# Altium schematic sheet main.SchDoc(main)
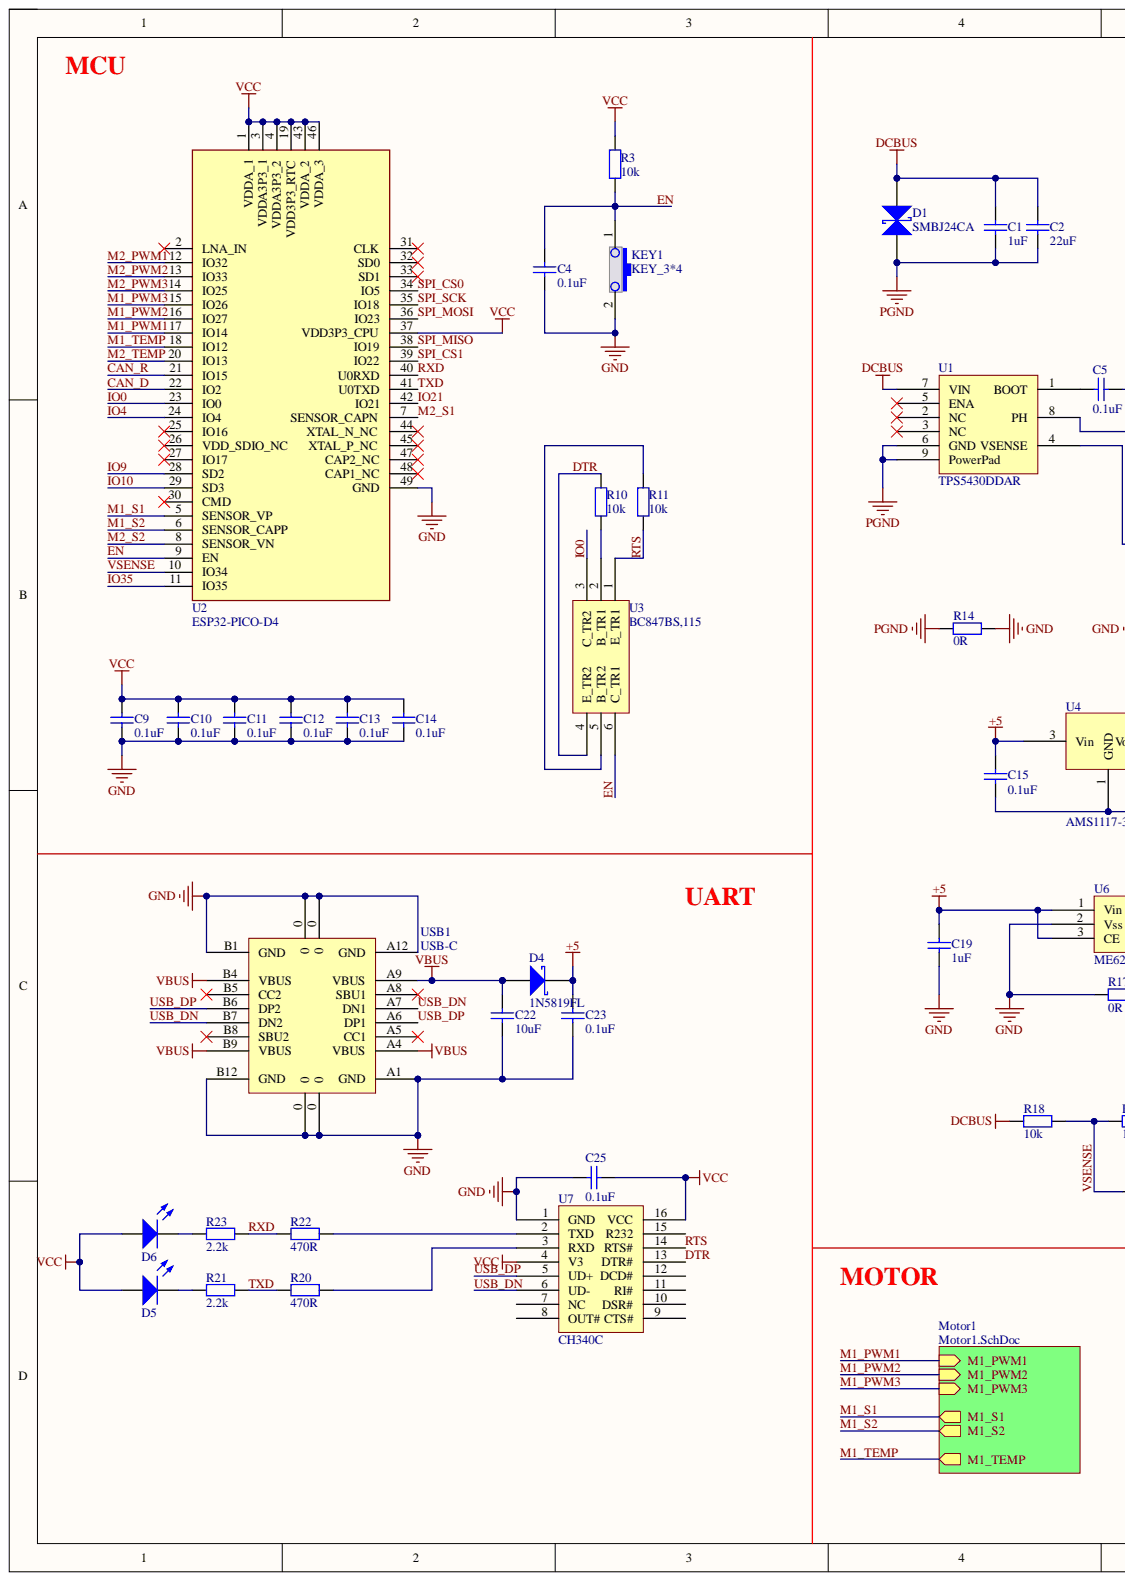
[diagram p0: main.SchDoc, left]
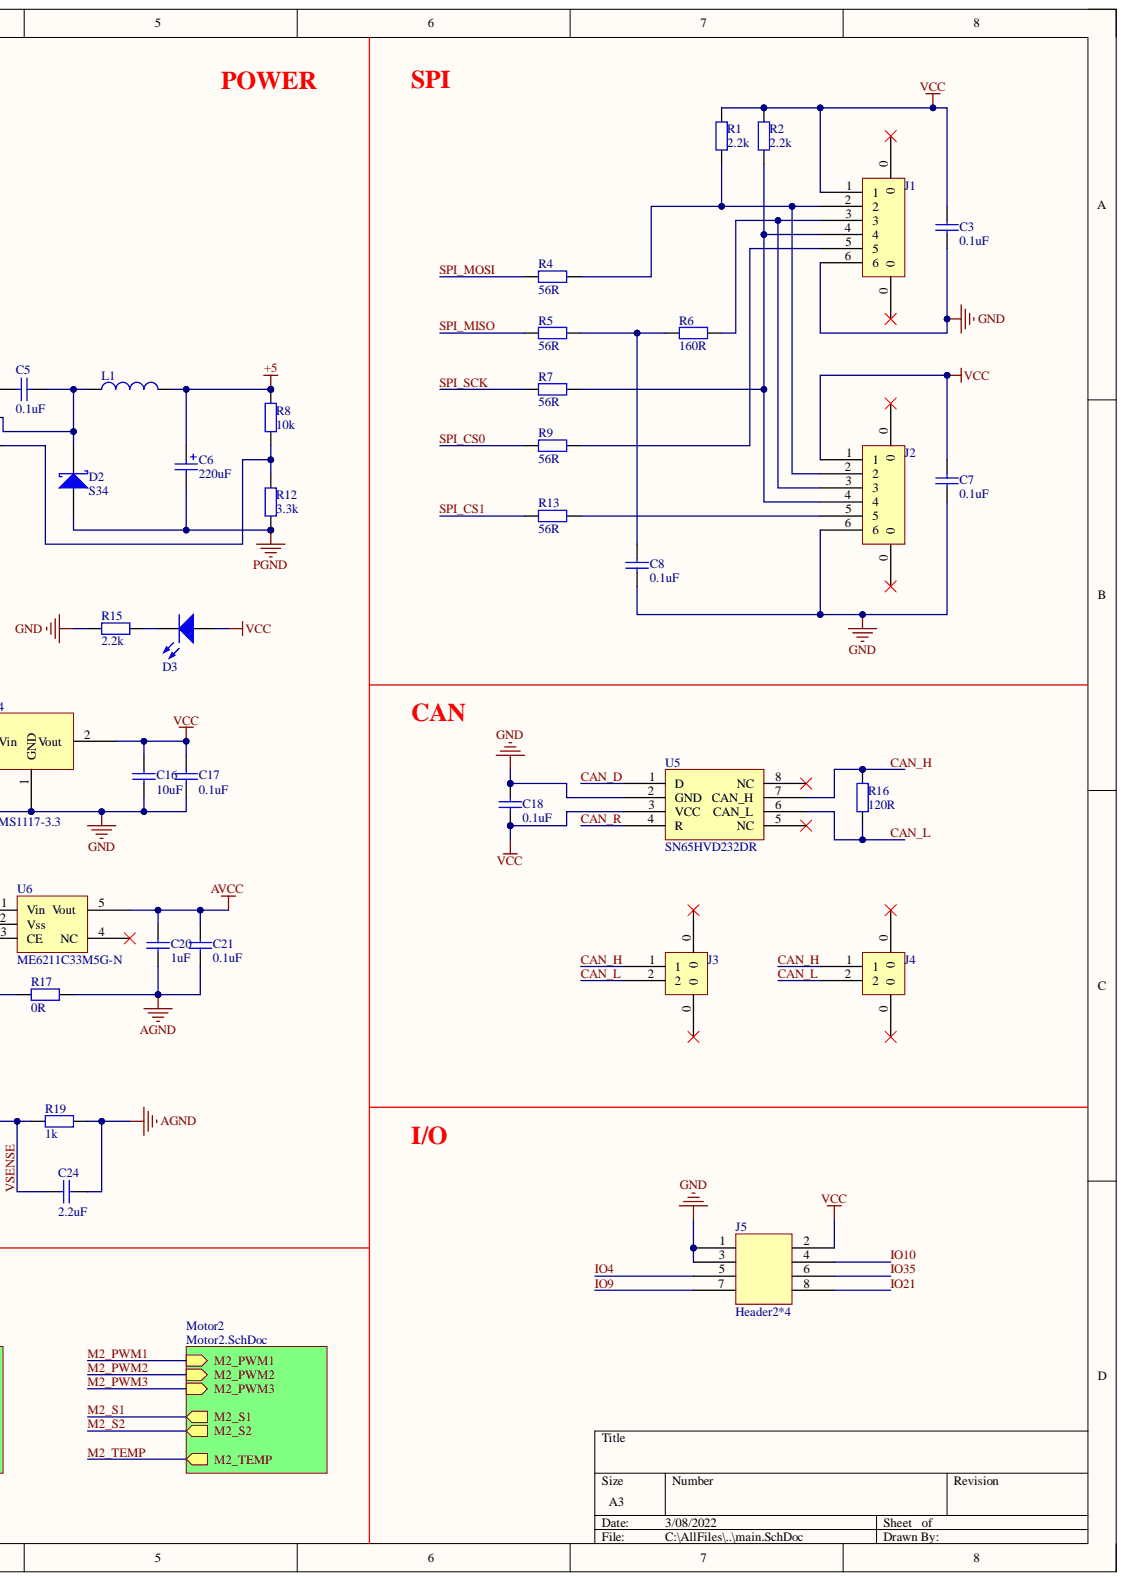
[diagram p1: main.SchDoc, right]

## Parts
C1  1uF  [CAP_1206]
C2  22uF  [CAP_1206]
C3  0.1uF  [CAP_0402]
C4  0.1uF  [CAP_0402]
C5  0.1uF  [CAP_0603]
C6  220uF  [CAP-ALU-D8_6.3x6.6x7.7]
C7  0.1uF  [CAP_0402]
C8  0.1uF  [CAP_0402]
C9  0.1uF  [CAP_0402]
C10  0.1uF  [CAP_0402]
C11  0.1uF  [CAP_0402]
C12  0.1uF  [CAP_0402]
C13  0.1uF  [CAP_0402]
C14  0.1uF  [CAP_0402]
C15  0.1uF  [CAP_0603]
C16  10uF  [CAP_0603]
C17  0.1uF  [CAP_0603]
C18  0.1uF  [CAP_0402]
C19  1uF  [CAP_0603]
C20  1uF  [CAP_0603]
C21  0.1uF  [CAP_0603]
C22  10uF  [CAP_0603]
C23  0.1uF  [CAP_0603]
C24  2.2uF  [CAP_0402]
C25  0.1uF  [CAP_0402]
D1  SMBJ24CA  [SMB(DO-214)]
D2  S34  [SOD-123FL]
D3  LED  [LED_0603_B]  (value not drawn)
D4  1N5819FL  [SOD-123FL]
D5  LED  [LED_0603_B]  (value not drawn)
D6  LED  [LED_0603_G]  (value not drawn)
J1  插座 1*6P 卧贴  [1.0T-6P]  (value not drawn)
J2  插座 1*6P 卧贴  [1.0T-6P]  (value not drawn)
J3  插座 1*2P 卧贴  [1.0T-2P]  (value not drawn)
J4  插座 1*2P 卧贴  [1.0T-2P]  (value not drawn)
J5  Header2*4  [Header2*4]
KEY1  KEY_3*4  [KEY_3*4]
L1  22uH  [SLF0504]  (value not drawn)
R1  2.2k  [RES-0402]
R2  2.2k  [RES-0402]
R3  10k  [RES-0402]
R4  56R  [RES-0402]
R5  56R  [RES-0402]
R6  160R  [RES-0402]
R7  56R  [RES-0402]
R8  10k  [RES-0402]
R9  56R  [RES-0402]
R10  10k  [RES-0603]
R11  10k  [RES-0603]
R12  3.3k  [RES-0402]
R13  56R  [RES-0402]
R14  0R  [RES-1206]
R15  2.2k  [RES-0402]
R16  120R  [RES-0402]
R17  0R  [RES-0805]
R18  10k  [RES-0402]
R19  1k  [RES-0402]
R20  470R  [RES-0402]
R21  2.2k  [RES-0402]
R22  470R  [RES-0402]
R23  2.2k  [RES-0402]
U1  TPS5430DDAR  [SO-8]
U2  ESP32-PICO-D4  [QFN-48_7x7x05P]
U3  BC847BS,115  [SOT363]
U4  AMS1117-3.3  [SOT-223]
U5  SN65HVD232DR  [SOIC-8_150mil]
U6  ME6211C33M5G-N  [SOT-23-5]
U7  CH340C  [SOP-16]
USB1  USB-C  [USB-TYPE-C_16PIN-MALE-TYPE-C-31-M-12]

## Nets
+5: C6.1 C15.2 C19.2 C23.2 D4.2 L1.2 R8.1 U4.3 U6.1(Vin) U6.3(CE)
AGND: C20.1 C21.1 C24.1 R17.2 R19.2
AVCC: C20.2 C21.2 U6.5(Vout)
DCBUS: C1.1 C2.1 D1.2 R18.1 U1.7(VIN)
GND: C3.1 C4.1 C7.1 C8.1 C9.1 C10.1 C11.1 C12.1 C13.1 C14.1 C15.1 C16.1 C17.1 C18.1 C19.1 C22.1 C23.1 C25.2 J1.6 J2.6 J5.1 J5.3 KEY1.2 R14.2 R15.2 R17.1 U2.49(GND) U4.1 U5.2(GND) U6.2(Vss) U7.1(GND) USB1.0 USB1.A1(GND) USB1.A12(GND) USB1.B1(GND) USB1.B12(GND)
PGND: C1.2 C2.2 C6.2 D1.1 D2.1 R12.2 R14.1 U1.6(GND) U1.9(PowerPad)
VBUS: C22.2 D4.1 USB1.A4(VBUS) USB1.A9(VBUS) USB1.B4(VBUS) USB1.B9(VBUS)
VCC: C3.2 C7.2 C9.2 C10.2 C11.2 C12.2 C13.2 C14.2 C16.2 C17.2 C18.2 C25.1 D3.1 D5.1 D6.1 J1.1 J2.1 J5.2 R1.2 R2.2 R3.2 U2.1(VDDA_1) U2.3(VDDA3P3_1) U2.4(VDDA3P3_2) U2.19(VDD3P3_RTC) U2.37(VDD3P3_CPU) U2.43(VDDA_2) U2.46(VDDA_3) U4.2 U5.3(VCC) U7.4(V3) U7.16(VCC)
CAN_D: U2.22(IO2) U5.1(D)
CAN_H: J3.1 J4.1 R16.1 U5.7(CAN_H)
CAN_L: J3.2 J4.2 R16.2 U5.6(CAN_L)
CAN_R: U2.21(IO15) U5.4(R)
DTR: R10.2 U3.4(E_TR2) U7.13(DTR#)
EN: C4.2 KEY1.1 R3.1 U2.9(EN) U3.6(C_TR1)
IO0: U2.23(IO0) U3.3(C_TR2)
IO4: J5.5 U2.24(IO4)
IO9: J5.7 U2.28(SD2)
IO10: J5.4 U2.29(SD3)
IO21: J5.8 U2.42(IO21)
IO35: J5.6 U2.11(IO35)
M1_PWM1: U2.17(IO14)
M1_PWM2: U2.16(IO27)
M1_PWM3: U2.15(IO26)
M1_S1: U2.5(SENSOR_VP)
M1_S2: U2.6(SENSOR_CAPP)
M1_TEMP: U2.18(IO12)
M2_PWM1: U2.12(IO32)
M2_PWM2: U2.13(IO33)
M2_PWM3: U2.14(IO25)
M2_S1: U2.7(SENSOR_CAPN)
M2_S2: U2.8(SENSOR_VN)
M2_TEMP: U2.20(IO13)
RTS: R11.1 U3.1(E_TR1) U7.14(RTS#)
RXD: R22.1 R23.2 U2.40(U0RXD)
SPI_CS0: R9.1 U2.34(IO5)
SPI_CS1: R13.1 U2.39(IO22)
SPI_MISO: R5.1 U2.38(IO19)
SPI_MOSI: R4.1 U2.36(IO23)
SPI_SCK: R7.1 U2.35(IO18)
TXD: R20.1 R21.2 U2.41(U0TXD)
USB_DN: U7.6(UD-) USB1.A7(DN1) USB1.B7(DN2)
USB_DP: U7.5(UD+) USB1.A6(DP1) USB1.B6(DP2)
VSENSE: C24.2 R18.2 R19.1 U2.10(IO34)
NetC5_1: C5.1 D2.2 L1.1 U1.8(PH)
NetC5_2: C5.2 U1.1(BOOT)
NetC8_2: C8.2 R5.2 R6.1
NetD3_2: D3.2 R15.1
NetD5_2: D5.2 R21.1
NetD6_2: D6.2 R23.1
NetJ1_0: J1.0
NetJ1_2: J1.2 J2.2 R1.1 R4.2
NetJ1_3: J1.3 J2.3 R6.2
NetJ1_4: J1.4 J2.4 R2.1 R7.2
NetJ1_5: J1.5 R9.2
NetJ2_0: J2.0
NetJ2_5: J2.5 R13.2
NetJ3_0: J3.0
NetJ4_0: J4.0
NetR8_2: R8.2 R12.1 U1.4(VSENSE)
NetR10_1: R10.1 U3.2(B_TR1)
NetR11_2: R11.2 U3.5(B_TR2)
NetR20_2: R20.2 U7.3(RXD)
NetR22_2: R22.2 U7.2(TXD)
NetU1_2: U1.2(NC)
NetU1_3: U1.3(NC)
NetU1_5: U1.5(ENA)
NetU2_2: U2.2(LNA_IN)
NetU2_25: U2.25(IO16)
NetU2_26: U2.26(VDD_SDIO_NC)
NetU2_27: U2.27(IO17)
NetU2_30: U2.30(CMD)
NetU2_31: U2.31(CLK)
NetU2_32: U2.32(SD0)
NetU2_33: U2.33(SD1)
NetU2_44: U2.44(XTAL_N_NC)
NetU2_45: U2.45(XTAL_P_NC)
NetU2_47: U2.47(CAP2_NC)
NetU2_48: U2.48(CAP1_NC)
NetU5_5: U5.5(NC)
NetU5_8: U5.8(NC)
NetU6_4: U6.4(NC)
NetU7_7: U7.7(NC)
NetU7_8: U7.8(OUT#)
NetU7_9: U7.9(CTS#)
NetU7_10: U7.10(DSR#)
NetU7_11: U7.11(RI#)
NetU7_12: U7.12(DCD#)
NetU7_15: U7.15(R232)
NetUSB1_A5: USB1.A5(CC1)
NetUSB1_A8: USB1.A8(SBU1)
NetUSB1_B5: USB1.B5(CC2)
NetUSB1_B8: USB1.B8(SBU2)

## Library data of the parts
C1-C5 C7-C25 = Cap: Capacitor; Accuracy: 1%; Voltage: 5V; Component Kind: Standard; LatestRevisionDate: 17-Jul-2002; Published: 8-Jun-2000; Library Name: Miscellaneous DevicesUsers.SchLib; Publisher: Altium Limited
  C1 C19 C20: Value: 1uF
  C2: Value: 22uF
  C3-C5 C7-C15 C17 C18 C21 C23 C25: Value: 0.1uF
  C16 C22: Value: 10uF
  C24: Value: 2.2uF
C6 = Cap Pol: Polarized Capacitor (Radial); Accuracy: 1%; Component Kind: Standard; LatestRevisionDate: 17-Jul-2002; Published: 8-Jun-2000; Value: 220uF; Library Name: Miscellaneous DevicesUsers.SchLib; Publisher: JonesLee
D1 = Diode_Bipolar_TVS: Component Kind: Standard; Library Name: NetTie.SchLib
D2 D4 = Diode_TVS: Component Kind: Standard; Library Name: NetTie.SchLib
D3 D5 D6 = LED: Value: 0603-RED; Component Kind: Standard; LatestRevisionDate: 08-Jul-2005; LatestRevisionNote: Mech Layer 1 removed.; Package: 0603; PackageDescription: LED; 2 Leads; PackageReference: 0603; Published: 8-Jun-2000; Library Name: Miscellaneous DevicesUsers.SchLib; Publisher: JonesLee
J3 J4 = 插座 1*2P 卧贴: C145954; Component Kind: Standard; Library Name: NetTie.SchLib
J5 = Header2*4: C124386; Component Kind: Standard; Library Name: NetTie.SchLib
KEY1 = KEY_3*4: C707339; Component Kind: Standard; Library Name: NetTie.SchLib
L1 = Inductor: Component Kind: Standard; Library Name: NetTie.SchLib
R1-R23 = Res: Resistor; Accuracy: 1%; Voltage: 5V; Component Kind: Standard; LatestRevisionDate: 17-Jul-2002; Published: 8-Jun-2000; Library Name: Miscellaneous DevicesUsers.SchLib; Publisher: JonesLee
  R1 R2 R15 R21 R23: Value: 2.2k
  R3 R8 R10 R11 R18: Value: 10k
  R4 R5 R7 R9 R13: Value: 56R
  R6: Value: 160R
  R12: Value: 3.3k
  R14 R17: Value: 0R
  R16: Value: 120R
  R19: Value: 1k
  R20 R22: Value: 470R
U1 = TPS5430DDAR: C9864; Component Kind: Standard; Library Name: NetTie.SchLib
U2 = ESP32-PICO-D4: C193707; Component Kind: Standard; Library Name: NetTie.SchLib
U3 = BC847BS,115: NPN/NPN general-purpose transistor pair in a very small SOT363 (SC-88) Surface-Mounted Device (SMD) plastic package.; Component Kind: Standard; Library Name: NetTie.SchLib
U4 = AMS1117-3.3: C347222; Component Kind: Standard; Library Name: NetTie.SchLib
U5 = SN65HVD232DR: C30530; Component Kind: Standard; Library Name: NetTie.SchLib
U6 = ME6211C33M5G-N: C82942; Component Kind: Standard; Library Name: NetTie.SchLib
U7 = CH340C: C84681; Component Kind: Standard; Library Name: NetTie.SchLib
USB1 = USB-C: C165948; Component Kind: Standard; Library Name: NetTie.SchLib
J1 J2 = 插座 1*6P 卧贴: Component Kind: Standard; Library Name: NetTie.SchLib
